# Altium schematic sheet Power Converter 5V as TO-3 replacement.SchDoc("Power Converter 5V as TO-3 replacement")
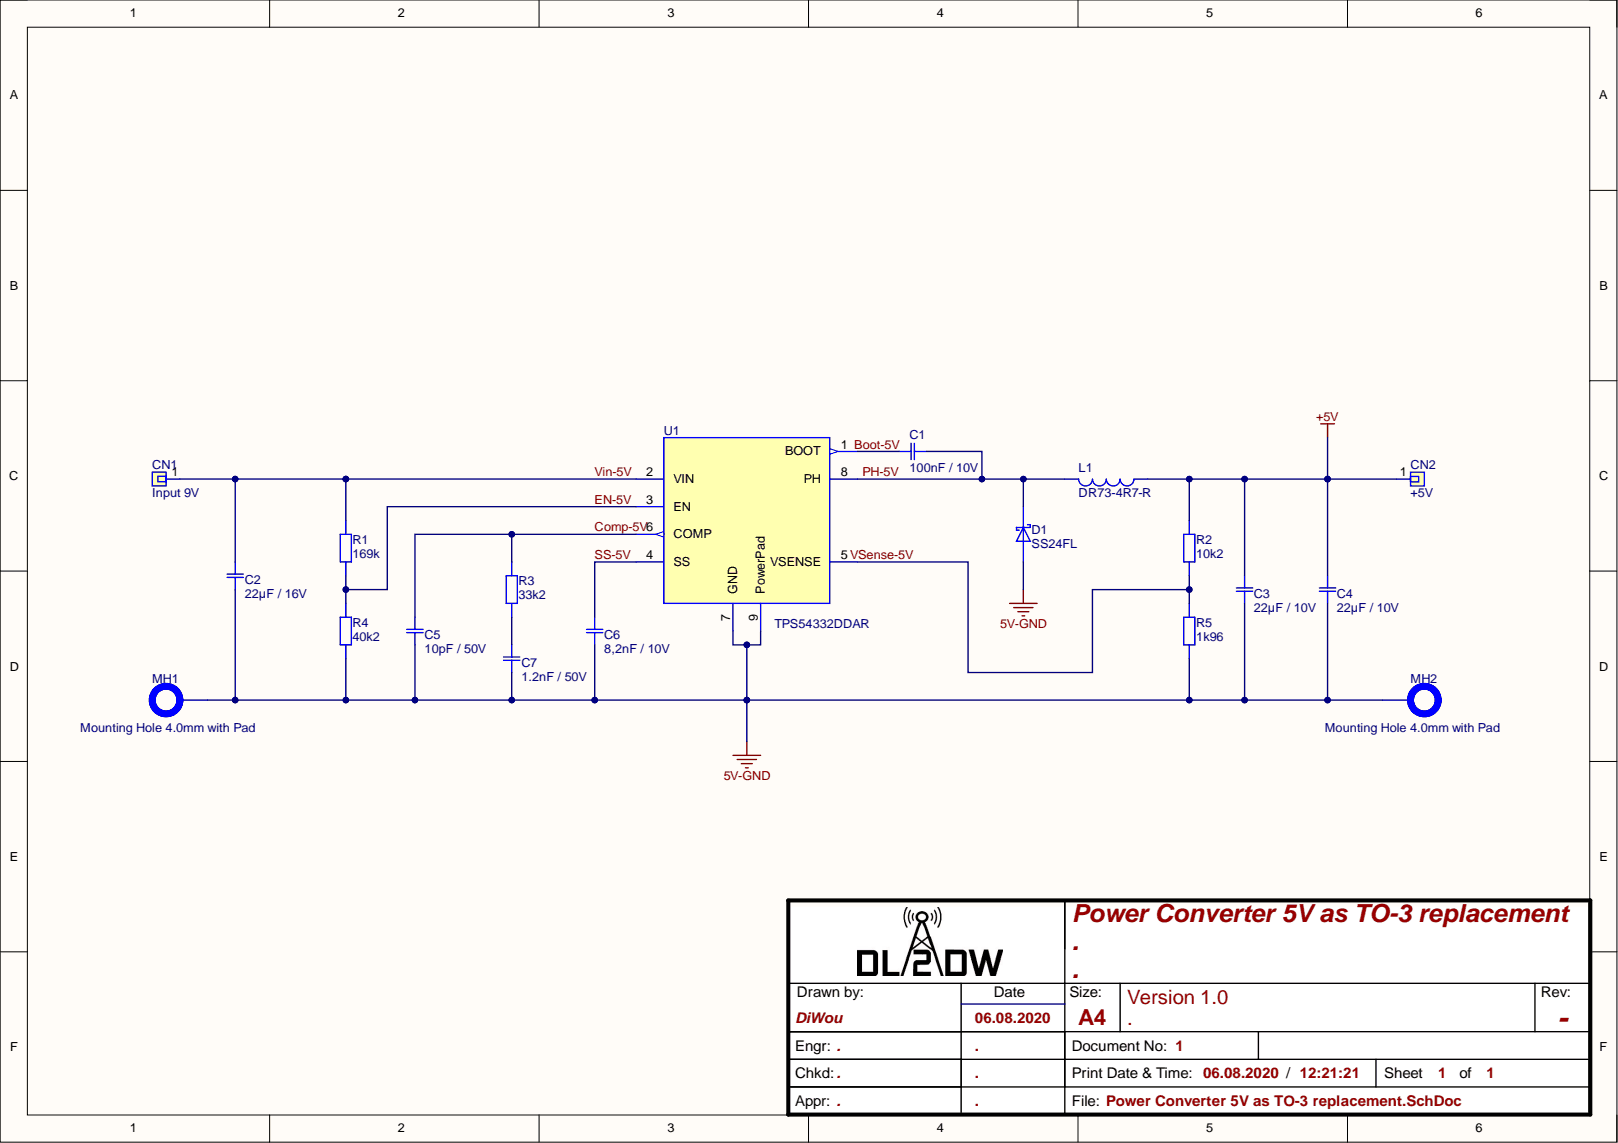
[diagram p0: Power Converter 5V as TO-3 replacement.SchDoc]

## Parts
C1  100nF / 10V  Capacitance: 100nF  [CAP CER SMD 0402 100nF 10V Murata GRM155R71A104KA01D]
C2  22µF / 16V  [CAP CER SMD 1210 22µF 16V Murata GRM32ER61C226ME20L]
C3  22µF / 10V  [CAP CER SMD 0805 22µF 10V Murata GRM21BR61A226ME44L]
C4  22µF / 10V  Voltage: 10V  [CAP CER SMD 0805 22µF 10V Murata GRM21BR61A226ME44L]
C5  10pF / 50V  Capacitance: 10pF  [CAP CER SMD 0805 10pF 50V Samsung CL21C100JBANNNC]
C6  8,2nF / 10V  [CAP CER SMD 0201 8,2nF 10V Murata GRM033R71A822KA01D]
C7  1.2nF / 50V  Capacitance: 1.2nF  Voltage Rating: 50V  [CAP CER SMD 0805 1.2nF 50V Samsung CL21C122JBFNNNE]
CN1  Input 9V  [Printed Circuit Pin 1.02mm 3125-2-00-80-00-00-08-0]
CN2  +5V  [Printed Circuit Pin 1.02mm 3125-2-00-80-00-00-08-0]
D1  SS24FL  [SS24FL]
L1  DR73-4R7-R  [Inductor SMD 4.7µH Eatont DR73-4R7-R]
MH1  Mounting Hole 4.0mm with Pad  [Mounting Hole 4mm with Pad]  (no BOM)
MH2  Mounting Hole 4.0mm with Pad  [Mounting Hole 4mm with Pad]  (no BOM)
R1  169k  [RES SMD 0402 169k Vishay CRCW0402169KFKED]
R2  10k2  [RES SMD 0402 10k2 Vishay CRCW040210K2FKED]
R3  33k2  [RES SMD 0402 33k2 Vishay CRCW040233K2FKED]
R4  40k2  [RES SMD 0402 40k2 Vishay CRCW040240K2FKED]
R5  1k96  [RES SMD 0402 1k96 Vishay CRCW04021K96FKED]
U1  TPS54332DDAR  [DC-DC Converter (Buck) TPS54332DDAR SO-Power Pad]

## Nets
5V-GND: C2.1 C3.1 C3.2 C4.1 C4.2 C5.1 C6.1 C7.1 CN2.1 D1.2 L1.2 MH1.1 MH2.1 R2.2 R4.1 R5.1 U1.7(GND) U1.9(PowerPad)
Boot-5V: C1.1 U1.1(BOOT)
Comp-5V: C5.2 R3.2 U1.6(COMP)
EN-5V: R1.1 R4.2 U1.3(EN)
PH-5V: C1.2 D1.1 L1.1 U1.8(PH)
SS-5V: C6.2 U1.4(SS)
Vin-5V: C2.2 CN1.1 R1.2 U1.2(VIN)
VSense-5V: R2.1 R5.2 U1.5(VSENSE)
NetC7_2: C7.2 R3.1

## Library data of the parts
C1 = CMP-Capacitors-Ceramic_Capacitors-00034-1: CAP CER 0.1UF 10V X7R 0402; Dissipation Factor: 0.035%; Tolerance: 10%; Case Code (Imperial): 0402; Case Code (Metric): 1005; Case/Package: 0402; Component Kind: Standard; Depth: 0.5mm; Dielectric: X7R; Height: 0.5mm; Lead Free: Lead Free; Length: 1mm; Library Name: PCB-Tech Cloud; Max Operating Temperature: 125°C; Min Operating Temperature: -55°C; Mount: Surface Mount; Number of Pins: 2; Package Quantity: 1; Packaging: Tape and Reel; Radiation Hardening: No; REACH SVHC: No SVHC; Termination: SMD/SMT; Thickness: 0.022inch; Voltage: 10V; Voltage Rating: 10V; Voltage Rating (DC): 10V; Width: 0.02inch
C2 = CMP-Capacitors-Ceramic_Capacitors-00036-1: Ceramic capacitor SMD 1210 22 µF 16 V 20 %; Capacitance: 22uF; Dielectric: X5R; Tolerance: 20%; Case Code (Imperial): 1210; Case Code (Metric): 3225; Case/Package: 1210; Component Kind: Standard; Depth: 2.5mm; Height: 2.5mm; Lead Free: Lead Free; Length: 3.2mm; Library Name: PCB-Tech Cloud; Material: Ceramic; Max Operating Temperature: 85°C; Min Operating Temperature: -55°C; Mount: Surface Mount; Package Quantity: 1000; Packaging: Tape and Reel; Radiation Hardening: No; Termination: SMD/SMT; Thickness: 0.106inch; Voltage: 16V; Voltage Rating: 16V; Voltage Rating (DC): 16V; Width: 0.098inch
C3 C4 = CMP-Capacitors-Ceramic_Capacitors-00038-1: Multilayer Ceramic Capacitors MLCC - SMD/SMT 0805 22uF 10volts X5R 20%; Dissipation Factor: 0.035%; Capacitance: 22uF; Case Code (Imperial): 0805; Case Code (Metric): 2012; Case/Package: 0805; Component Kind: Standard; Composition: Ceramic; Depth: 1.25mm; Dielectric: X5R; Dielectric Material: Ceramic; Height: 1.25mm; Lead Free: Lead Free; Length: 2mm; Library Name: PCB-Tech Cloud; Max Operating Temperature: 85°C; Min Operating Temperature: -55°C; Mount: Surface Mount; Package Quantity: 3000; Packaging: Tape and Reel; Termination: SMD/SMT; Thickness: 0.057inch; Tolerance: 20%; Voltage Rating: 10V; Voltage Rating (DC): 10V; Weight: 0.000335oz; Width: 1.25mm
  C3: Voltage: 10V
C5 = CMP-Capacitors-Ceramic_Capacitors-00031-1: Cap Ceramic 10pF 50VDC C0G 5% SMD 0805 Paper T/R; Case Code (Imperial): 0805; Case Code (Metric): 2012; Case/Package: 0805; Component Kind: Standard; Contact Plating: Tin; Depth: 1.25mm; Dielectric: C0G; Height: 0.65mm; Length: 2mm; Library Name: PCB-Tech Cloud; Max Operating Temperature: 125°C; Min Operating Temperature: -55°C; Mount: Surface Mount; Package Quantity: 1; Packaging: Tape and Reel; Radiation Hardening: No; Thickness: 0.03inch; Tolerance: 5%; Voltage Rating: 50V; Width: 0.049inch
C6 = CMP-Capacitors-Ceramic_Capacitors-00033-1: Multilayer Ceramic Capacitors MLCC - SMD/SMT 0.0082uF 10volts 10%; Capacitance: 8.2nF; Dielectric: X7R; Case Code (Imperial): 0201; Case Code (Metric): 0603; Case/Package: 0201; Component Kind: Standard; Depth: 0.3mm; Height: 0.3mm; Length: 0.6mm; Library Name: PCB-Tech Cloud; Max Operating Temperature: 125°C; Min Operating Temperature: -55°C; Mount: Surface Mount; Package Quantity: 15000; Packaging: Tape and Reel; Radiation Hardening: No; Termination: SMD/SMT; Thickness: 0.013inch; Tolerance: 10%; Voltage Rating: 10V; Voltage Rating (DC): 10V; Width: 0.012inch
C7 = CMP-Capacitors-Ceramic_Capacitors-00037-1: Ceramic capacitor SMD-0805 1.2nF /50V NP0# J +-5% SAMSUNG , RoHS; Tolerance: 5%; Case Code (Imperial): 0805; Case Code (Metric): 2012; Case/Package: 0805; Component Kind: Standard; Lead Free: Lead Free; Length: 0.079inch; Library Name: PCB-Tech Cloud; Max Operating Temperature: 125°C; Min Operating Temperature: -55°C; Mount: Surface Mount; Package Quantity: 1; Packaging: Cut Tape; Thickness: 0.053inch; Voltage Rating (DC): 50V; Width: 0.049inch
CN1 CN2 = CMP-Mechanicals-00020-1: CONN PC PIN CIRC 0.040DIA TIN; Board Thickness: 0.062inch; Flange Diameter: 0.071inch; Gender: Male; Hole Diameter: 1.09mm; Insulation: Non-Insulated; Mounting Hole Diameter: 0.043inch; Outside Diameter: 1.8mm; Component Kind: Standard; Contact Material: Brass; Contact Plating: Tin; Length: 0.402inch; Library Name: PCB-Tech Cloud; Material: Brass; Mount: Through Hole; Package Quantity: 500; Packaging: Bulk; Plating: Tin; Termination: PC Pin
D1 = CMP-Diodes-00012-3: 40V, 2A SCHOTTKY IN SOD123F; Element Configuration: Single; Max Forward Surge Current (Ifsm): 50A; Reverse Recovery Time: 9.495ns; Average Rectified Current: 2A; Case/Package: SOD; Component Kind: Standard; Library Name: PCB-Tech Cloud; Max Repetitive Reverse Voltage (Vrrm): 40V; Max Reverse Voltage (DC): 40V; Mount: Surface Mount; Number of Pins: 2; Packaging: Tape and Reel; Weight: 0.0276g
L1 = CMP-Inductors-00014-2: Ind Power Shielded Wirewound 4.7uH 20% 100KHz Ferrite 3.09A T/R; DC Resistance (DCR): 29.7mR; Saturation Current: 3.78A; Case/Package: Nonstandard; Component Kind: Standard; Composition: Wirewound; Core Material: Ferrite; Current Rating: 3.09A; Depth: 7.6mm; Height: 3.55mm; Height - Seated (Max): 0.139inch; Inductance: 4.7uH; Inductance Tolerance: 20%; Lead Free: Lead Free; Length: 7.6mm; Library Name: PCB-Tech Cloud; Max DC Current: 3.09A; Max Operating Temperature: 125°C; Military Standard: Not; Min Operating Temperature: -40°C; Mount: Surface Mount; Number of Pins: 2; Package Quantity: 1350; Packaging: Tape and Reel; Radiation Hardening: No; REACH SVHC: No SVHC; RMS Current (Irms): 3.09A; Self Resonant Frequency: 100kHz; Shielding: Shielded; Termination: SMD/SMT; Test Frequency: 100kHz; Tolerance: 20%; Weight: 0.032oz; Width: 6mm
MH1 MH2 = CMP-Mechanicals-00019-2: Description: Mounting Hole 4.0mm with Pad; Library Name: PCB-Tech Cloud
R1 = CMP-Resistors-00008-1: VISHAY - CRCW0402169KFKED - RES, THICK FILM, 169K, 1%, 0.063W, 0402, REEL; Max Power Dissipation: 63mW; Resistance: 169kR; Temperature Coefficient: 100ppm/°C; Voltage Rating: 50V; Case Code (Imperial): 0402; Case Code (Metric): 1005; Case/Package: 0402; Component Kind: Standard; Composition: Thick Film; Contact Plating: Tin; Depth: 0.5mm; Features: Automotive AEC-Q200; Height: 0.35mm; Lead Free: Lead Free; Length: 1mm; Library Name: PCB-Tech Cloud; Max Operating Temperature: 155°C; Military Standard: Not; Min Operating Temperature: -55°C; Mount: Surface Mount; Number of Pins: 2; Number of Terminations: 2; Package Quantity: 10; Packaging: Tape and Reel; Power Rating: 63mW; Radiation Hardening: No; REACH SVHC: Unknown; Termination: SMD/SMT; Tolerance: 1%; Voltage Rating (DC): 50V; Weight: 2.3E-05oz; Width: 0.02inch
R2 = CMP-Resistors-00024-1: VISHAY         CRCW040210K2FKED.            Surface Mount Chip Resistor, Thick Film, AEC-Q200 CRCW Series, 10.2 kohm, 63 mW,  1%, 50 V; Max Power Dissipation: 63mW; Resistance: 10.2kR; Temperature Coefficient: 100ppm/°C; Voltage Rating: 50V; Case Code (Imperial): 0402; Case Code (Metric): 1005; Case/Package: 0402; Component Kind: Standard; Composition: Thick Film; Contact Plating: Tin; Depth: 0.5mm; Features: Automotive AEC-Q200; Height: 0.35mm; Length: 1mm; Library Name: PCB-Tech Cloud; Max Operating Temperature: 155°C; Military Standard: Not; Min Operating Temperature: -55°C; Mount: Surface Mount; Number of Pins: 2; Number of Terminations: 2; Package Quantity: 10; Packaging: Tape and Reel; Power Rating: 63mW; Radiation Hardening: No; REACH SVHC: Unknown; Termination: SMD/SMT; Tolerance: 1%; Weight: 2.3E-05oz; Width: 0.5mm
R3 = CMP-Resistors-00037-1: Res Thick Film 0402 33.2K Ohm 1% 1/16W 100ppm/C Molded SMD Paper T/R; Max Power Dissipation: 63mW; Resistance: 33.2kR; Temperature Coefficient: 100ppm/°C; Voltage Rating: 50V; Case Code (Imperial): 0402; Case Code (Metric): 1005; Case/Package: 0402; Component Kind: Standard; Composition: Thick Film; Contact Plating: Tin; Depth: 0.5mm; Features: Automotive AEC-Q200; Height: 0.35mm; Lead Free: Lead Free; Length: 1mm; Library Name: PCB-Tech Cloud; Max Operating Temperature: 155°C; Military Standard: Not; Min Operating Temperature: -55°C; Mount: Surface Mount; Number of Pins: 2; Number of Terminations: 2; Package Quantity: 10; Packaging: Tape and Reel; Power Rating: 63mW; Radiation Hardening: No; REACH SVHC: Unknown; Termination: SMD/SMT; Tolerance: 1%; Voltage Rating (DC): 50V; Weight: 2.3E-05oz; Width: 0.5mm
R4 = CMP-Resistors-00036-1: Res Thick Film 0402 40.2K Ohm 1% 1/16W 100ppm/C Molded SMD SMD Paper T/R; Max Power Dissipation: 63mW; Resistance: 40.2kR; Temperature Coefficient: 100ppm/°C; Voltage Rating: 50V; Case Code (Imperial): 0402; Case Code (Metric): 1005; Case/Package: 0402; Component Kind: Standard; Composition: Thick Film; Contact Plating: Tin; Depth: 0.5mm; Features: Automotive AEC-Q200; Height: 0.35mm; Lead Free: Lead Free; Length: 1mm; Library Name: PCB-Tech Cloud; Max Operating Temperature: 155°C; Military Standard: Not; Min Operating Temperature: -55°C; Number of Terminations: 2; Package Quantity: 10; Packaging: Tape and Reel; Power Rating: 63mW; Radiation Hardening: No; REACH SVHC: Unknown; Termination: SMD/SMT; Tolerance: 1%; Voltage Rating (DC): 50V; Weight: 2.3E-05oz; Width: 0.5mm
R5 = CMP-Resistors-00038-1: VISHAY         CRCW04021K96FKED             RES, THICK FILM, 1K96, 1%, 0.063W, 0402; Max Power Dissipation: 63mW; Resistance: 1.96kR; Temperature Coefficient: 100ppm/°C; Voltage Rating: 50V; Case Code (Imperial): 0402; Case Code (Metric): 1005; Case/Package: 0402; Component Kind: Standard; Composition: Thick Film; Contact Plating: Tin; Depth: 0.5mm; Features: Automotive AEC-Q200; Height: 0.35mm; Length: 1mm; Library Name: PCB-Tech Cloud; Max Operating Temperature: 155°C; Military Standard: Not; Min Operating Temperature: -55°C; Number of Pins: 2; Number of Terminations: 2; Package Quantity: 10; Packaging: Tape and Reel; Power Rating: 63mW; Radiation Hardening: No; REACH SVHC: Unknown; Termination: SMD/SMT; Tolerance: 1%; Weight: 2.3E-05oz; Width: 0.5mm
U1 = CMP-ICs-Power_Supply-00013-5: TEXAS INSTRUMENTS - TPS54332DDAR - DC/DC CONV, BUCK, 1MHZ, SOIC-8; Max Input Voltage: 28V; Max Output Current: 3.5A; Max Output Voltage: 26.04V; Min Input Voltage: 3.5V; Min Output Voltage: 800mV; Number of Outputs: 1; Output Configuration: Positive; Output Type: Adjustable; Output Voltage: 25V; Quiescent Current: 82uA; Synchronous Rectifier: No; Component Kind: Standard; Contact Plating: Gold; Height: 1.55mm; Lead Free: Contains Lead; Length: 5mm; Library Name: PCB-Tech Cloud; Max Operating Temperature: 150°C; Min Operating Temperature: -40°C; Min Supply Voltage: 3.5V; Mount: Surface Mount; Number of Pins: 8; Operating Supply Voltage: 28V; Output Current: 3.5A; Package Quantity: 2500; Packaging: Tape and Reel; Radiation Hardening: No; REACH SVHC: No SVHC; Switching Frequency: 1MHz; Weight: 0.00249oz; Width: 4mm
